# Altium schematic sheet CC2650RC_4MOD1106_RF_part_rev1_2.SchDoc(CC2650RC_4MOD1106_RF_part_rev1_2)
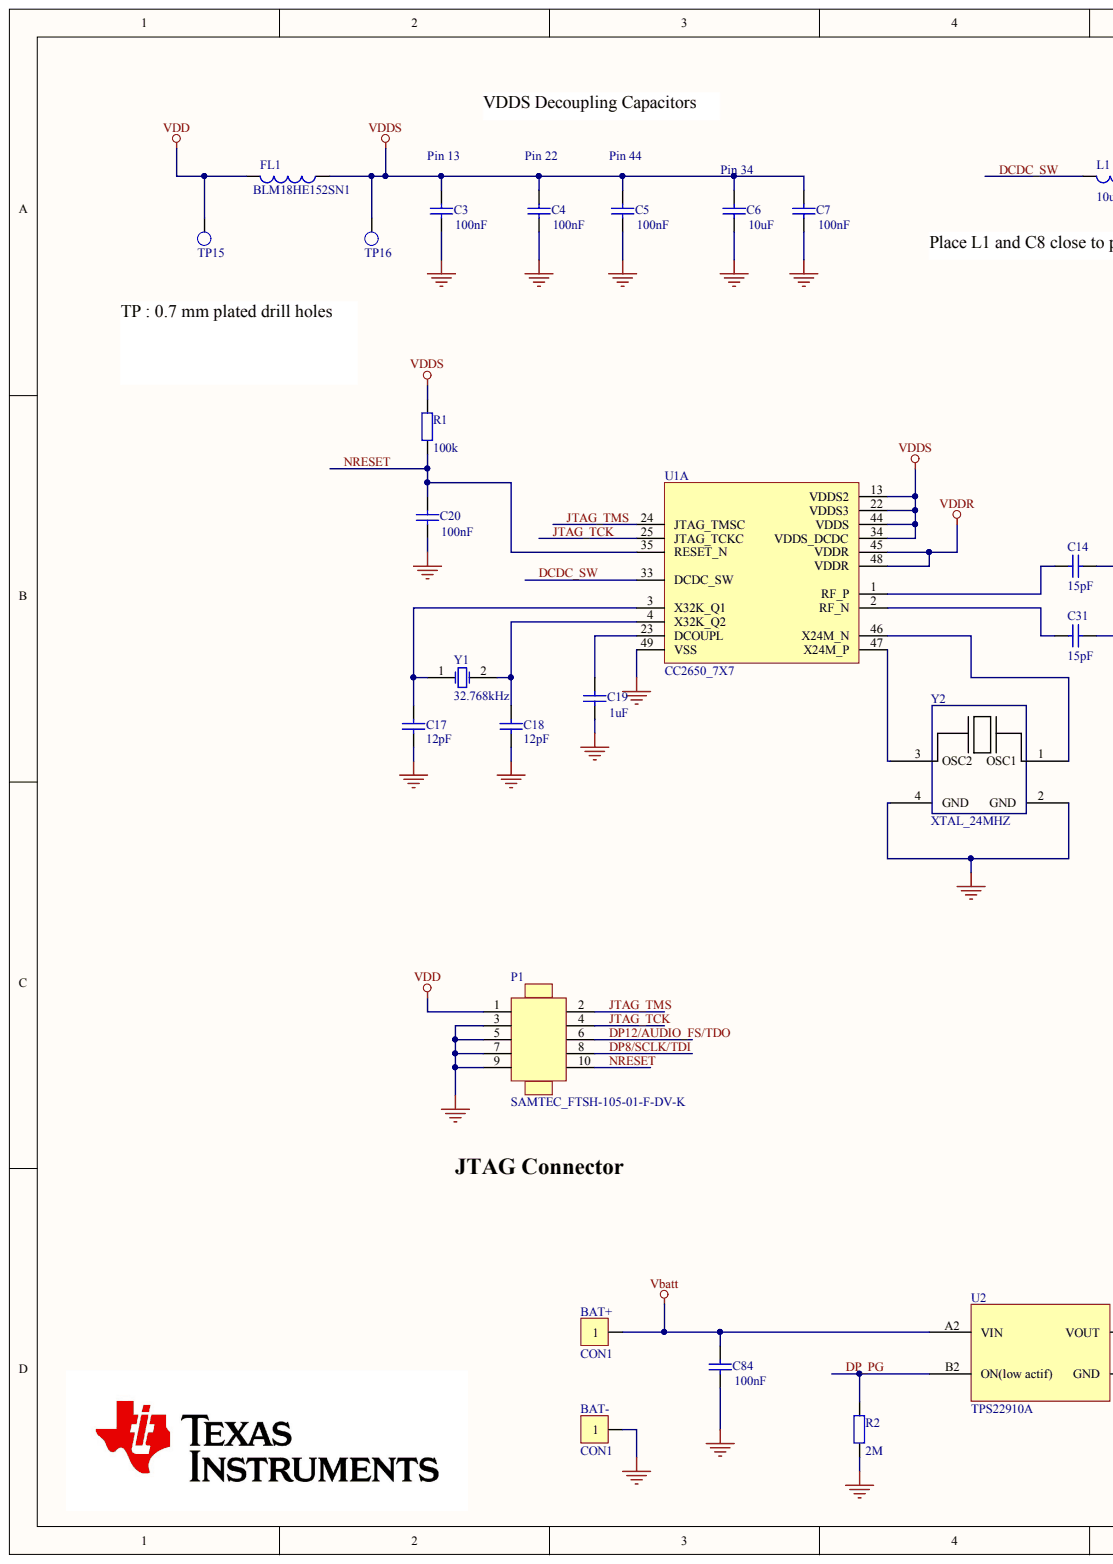
[diagram p0: CC2650RC_4MOD1106_RF_part_rev1_2.SchDoc, left]
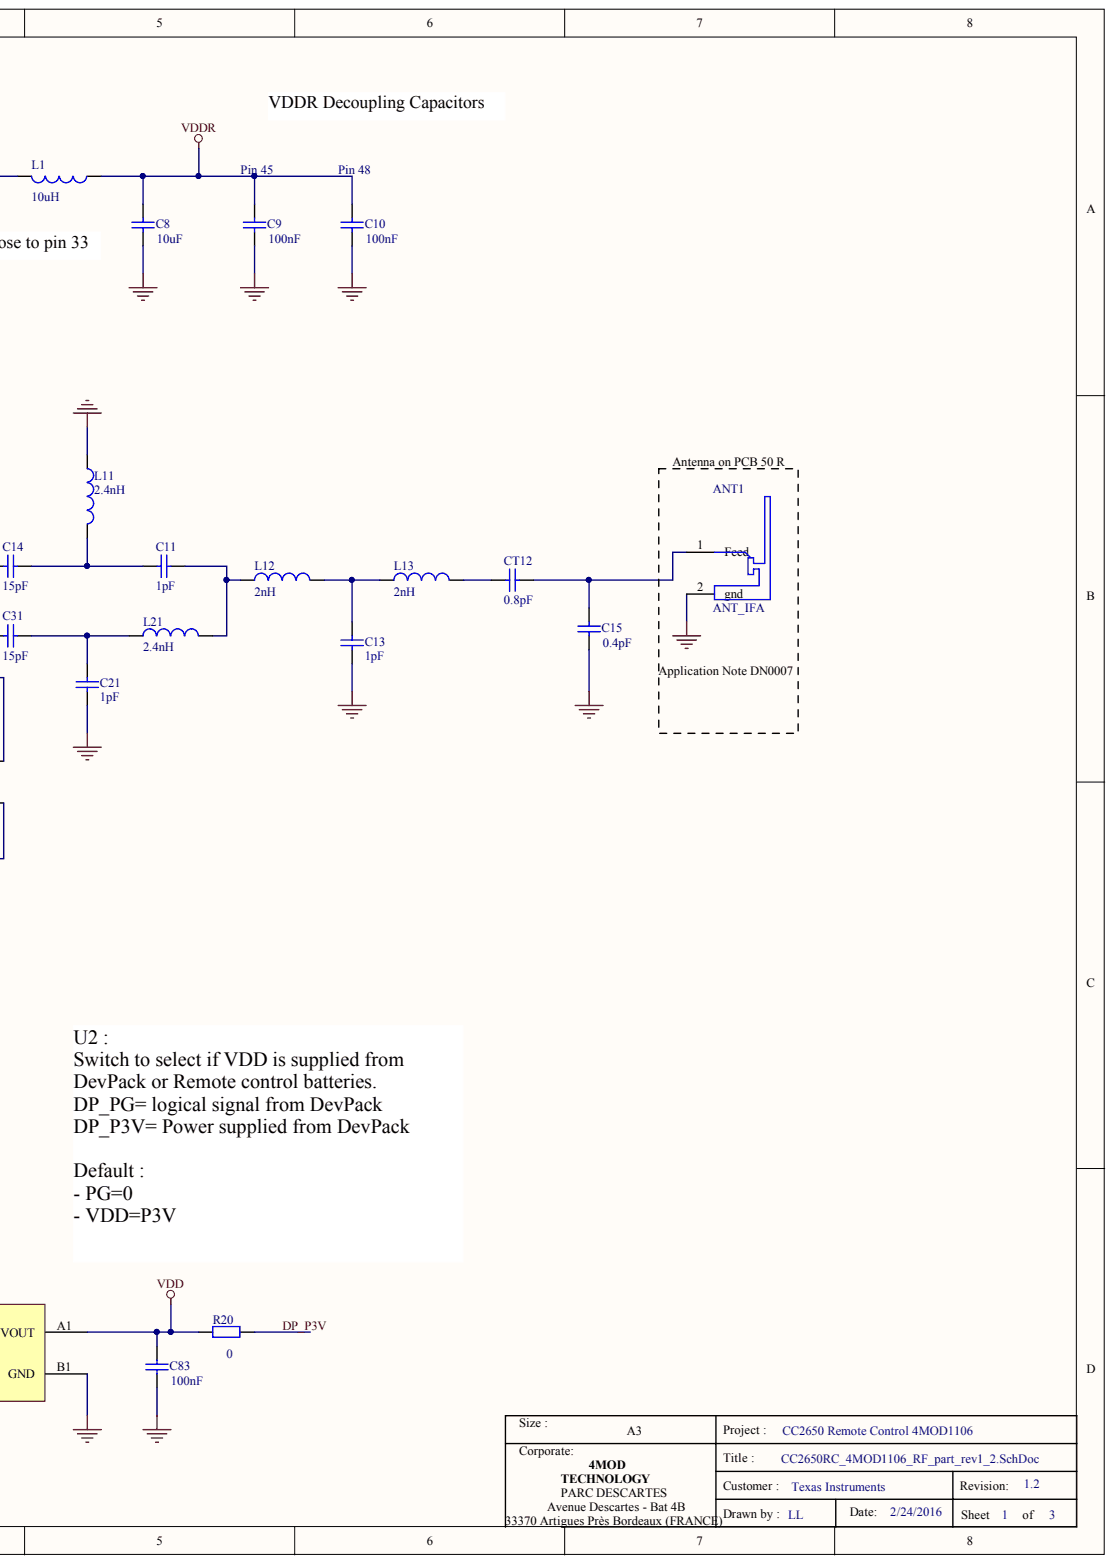
[diagram p1: CC2650RC_4MOD1106_RF_part_rev1_2.SchDoc, right]

## Parts
ANT1  ANT_IFA  [ANT_IFA_TI_DN0007]
BAT+  CON1  [SPRING_POSITIVE_4MOD1106]
BAT-  CON1  [SPRING_NEGATIVE_4MOD1106]
C3  Pin 13  value 100nF  [C402_4MOD]
C4  Pin 22  value 100nF  [C402_4MOD]
C5  Pin 44  value 100nF  [C402_4MOD]
C6  Pin 34  value 10uF  [C603_4MOD]
C7  value 100nF  [C402_4MOD]
C8  value 10uF  [C603_4MOD]
C9  Pin 45  value 100nF  [C402_4MOD]
C10  Pin 48  value 100nF  [C402_4MOD]
C11  value 1pF  [C402_4MOD]
C13  value 1pF  [C402_4MOD]
C14  value 15pF  [C402_4MOD]
C15  0.4pF  [C402_4MOD]
C17  value 12pF  [C402_4MOD]
C18  value 12pF  [C402_4MOD]
C19  value 1uF  [C402_4MOD]
C20  value 100nF  [C402_4MOD]
C21  value 1pF  [C402_4MOD]
C31  value 15pF  [C402_4MOD]
C83  value 100nF  [C402_4MOD]
C84  value 100nF  [C402_4MOD]
CT12  0.8pF  [C402_4MOD]
FL1  BLM18HE152SN1  [L0603_4MOD]
L1  10uH  [L0805_POWER_4MOD]
L11  value 2.4nH  [L0402_4MOD]
L12  value 2nH  [L0402_4MOD]
L13  value 2nH  [L0402_4MOD]
L21  value 2.4nH  [L0402_4MOD]
P1  SAMTEC_FTSH-105-01-F-DV-K  [HD2X5P127MM_POST]
R1  100k  [R402-4MOD]
R2  2M  [R402-4MOD]
R20  value 0  [R402-4MOD]
TP15  TEST POINT  [TP_CC2650RC]  (value not drawn)
TP16  TEST POINT  [TP_CC2650RC]  (value not drawn)
U1  CC2650_7X7  [CC26XX_QFN48(7x7)_0P5]  (part A)
U2  TPS22910A  [TPS22910A]
Y1  32.768kHz  [XTAL_SMD_3215(3.2X1.5)]
Y2  XTAL_24MHZ  [XTAL_SMD_3225(3.2X2.5)]

## Nets
GND: ANT1.2 BAT-.1 C3.1 C4.1 C5.1 C6.1 C7.1 C8.1 C9.1 C10.1 C13.2 C15.2 C17.1 C18.1 C19.1 C20.1 C21.2 C83.1 C84.1 L11.1 P1.3 P1.5 P1.7 P1.9 R2.2 U1.49(VSS) U2.B1(GND) Y2.2(GND) Y2.4(GND)
VBATT: BAT+.1 C84.2 U2.A2(VIN)
VDD: C83.2 FL1.2 P1.1 R20.1 TP15.1 U2.A1(VOUT)
VDDR: C8.2 C9.2 C10.2 L1.1 U1.45(VDDR) U1.48(VDDR)
VDDS: C3.2 C4.2 C5.2 C6.2 C7.2 FL1.1 R1.1 TP16.1 U1.13(VDDS2) U1.22(VDDS3) U1.34(VDDS_DCDC) U1.44(VDDS)
DCDC_SW: L1.2 U1.33(DCDC_SW)
DP8/SCLK/TDI: P1.8
DP12/AUDIO_FS/TDO: P1.6
DP_P3V: R20.2
DP_PG: R2.1 U2.B2(ON(low_actif))
JTAG_TCK: P1.4 U1.25(JTAG_TCKC)
JTAG_TMS: P1.2 U1.24(JTAG_TMSC)
NRESET: C20.2 P1.10 R1.2 U1.35(RESET_N)
NetANT1_1: ANT1.1 C15.1 CT12.2
NetC11_1: C11.1 L12.1 L21.2
NetC11_2: C11.2 C14.1 L11.2
NetC13_1: C13.1 L12.2 L13.1
NetC14_2: C14.2 U1.1(RF_P)
NetC17_2: C17.2 U1.3(X32K_Q1) Y1.1
NetC18_2: C18.2 U1.4(X32K_Q2) Y1.2
NetC19_2: C19.2 U1.23(DCOUPL)
NetC21_1: C21.1 C31.1 L21.1
NetC31_2: C31.2 U1.2(RF_N)
NetCT12_1: CT12.1 L13.2
NetU1_46: U1.46(X24M_N) Y2.1(OSC1)
NetU1_47: U1.47(X24M_P) Y2.3(OSC2)

## Library data of the parts
ANT1 = INVERTED_F_ANTENNA_IFA_v2: Antenna on PCB , IFA type, version 2; Component Kind: Standard; Library Name: 4MOD_PASSIVE.SCHLIB
BAT+ BAT- = CON1: Point test; Component Kind: Standard; PCB3D: HDR1x1; Library Name: PASSIF.SCHLIB
C3 = Cap: Capacitor, Ceramic X7R, 100nF, 6.3V, +/- 10%, 0402; Component Kind: Standard; LatestRevisionDate: 17-Jul-2002; LatestRevisionNote: Re-released for DXP Platform.; Library Name: Miscellaneous Devices.IntLib; PackageReference: RAD-0.3; Published: 8-Jun-2000; Signal Integrity: Cap; Simulation: CAP; Publisher: Altium Limited
C4 C5 C7 C9 C10 C20 C83 C84 = Cap: Capacitor, Ceramic X7R, 100nF, 6.3V, +/- 10%, SMD  case 0402; Component Kind: Standard; LatestRevisionDate: 17-Jul-2002; LatestRevisionNote: Re-released for DXP Platform.; Library Name: Miscellaneous Devices.IntLib; PackageReference: RAD-0.3; Published: 8-Jun-2000; Signal Integrity: Cap; Simulation: CAP; Publisher: Altium Limited
C6 C8 = Cap: Capacitor, Ceramic X5R, 10uF, 6.3V, +/- 10%, SMD case 0603; Component Kind: Standard; LatestRevisionDate: 17-Jul-2002; LatestRevisionNote: Re-released for DXP Platform.; Library Name: Miscellaneous Devices.IntLib; PackageReference: RAD-0.3; Published: 8-Jun-2000; Signal Integrity: Cap; Simulation: CAP; Publisher: Altium Limited
C11 C13 C21 = Cap: Ceramic Capacitor , NPO/COG, 1pF , 50V, 0.25pF, -55/+125 °C, smd case 0402; Component Kind: Standard; LatestRevisionDate: 17-Jul-2002; LatestRevisionNote: Re-released for DXP Platform.; Library Name: Miscellaneous Devices.IntLib; PackageReference: RAD-0.3; Published: 8-Jun-2000; Signal Integrity: Cap; Simulation: CAP; Publisher: Altium Limited
C14 = Cap: Ceramic Capacitor , NPO/COG, 15pF ,50V,  5 %, -55/+125 °C, smd case 0402; Component Kind: Standard; LatestRevisionDate: 17-Jul-2002; LatestRevisionNote: Re-released for DXP Platform.; Library Name: Miscellaneous Devices.IntLib; PackageReference: RAD-0.3; Published: 8-Jun-2000; Signal Integrity: Cap; Simulation: CAP; Publisher: Altium Limited
C15 = Cap: Ceramic Capacitor , NPO/COG, 0.4pF , 50V, 0.05pF, -55/+125 °C, smd case 0402; Component Kind: Standard; LatestRevisionDate: 17-Jul-2002; LatestRevisionNote: Re-released for DXP Platform.; Library Name: Miscellaneous Devices.IntLib; PackageReference: RAD-0.3; Published: 8-Jun-2000; Signal Integrity: Cap; Simulation: CAP; Value: 0.4pF; Publisher: Altium Limited
C17 C18 = Cap: Ceramic Capacitor, NPO/COG,12pF, 50V,+/-5%,-55/125°C, SMD case 0402; Component Kind: Standard; LatestRevisionDate: 17-Jul-2002; LatestRevisionNote: Re-released for DXP Platform.; Library Name: Miscellaneous Devices.IntLib; PackageReference: RAD-0.3; Published: 8-Jun-2000; Signal Integrity: Cap; Simulation: CAP; Publisher: Altium Limited
C19 = Cap: Ceramic Capacitor , X5V, 1uF, 6.3V, +/- 20%, SMD case 0402; Component Kind: Standard; LatestRevisionDate: 17-Jul-2002; LatestRevisionNote: Re-released for DXP Platform.; Library Name: Miscellaneous Devices.IntLib; PackageReference: RAD-0.3; Published: 8-Jun-2000; Signal Integrity: Cap; Simulation: CAP; Publisher: Altium Limited
C31 = Cap: Ceramic Capacitor , NPO/COG, 15pF ,50V,  5 %, -55/+125 °C, smd case; Component Kind: Standard; LatestRevisionDate: 17-Jul-2002; LatestRevisionNote: Re-released for DXP Platform.; Library Name: Miscellaneous Devices.IntLib; PackageReference: RAD-0.3; Published: 8-Jun-2000; Signal Integrity: Cap; Simulation: CAP; Publisher: Altium Limited
CT12 = Cap: Ceramic Capacitor , NPO/COG, 0.8pF , 50V, +/-0.05pF, -55/+125 °C, smd case 0402; Component Kind: Standard; LatestRevisionDate: 17-Jul-2002; LatestRevisionNote: Re-released for DXP Platform.; Library Name: Miscellaneous Devices.IntLib; PackageReference: RAD-0.3; Published: 8-Jun-2000; Signal Integrity: Cap; Simulation: CAP; Value: 0.8pF; Publisher: Altium Limited
FL1 = Inductor: FILTER, EMI, 1500@100MHz, -55DEGC/+125DEGC,BLM18HE152SN1 ,  SMD case 0603; Manufacturer: Generic Components; Class: Passive; Value: 1k5; Component Kind: Standard; Library Name: Miscellaneous Devices.IntLib; Published: 08/06/2000; Signal Integrity: Inductor; Simulation: INDUCTOR; SubClass: Inductor; Publisher: Altium Hobart Technology Centre
L1 = Inductor: Inductor, 2u2H, 0805, ±30%; Manufacturer: Generic Components; Class: Passive; Component Kind: Standard; Library Name: Miscellaneous Devices.IntLib; Published: 08/06/2000; Signal Integrity: Inductor; Simulation: INDUCTOR; SubClass: Inductor; Value: 10uH; Publisher: Altium Hobart Technology Centre
L11 L21 = Inductor: Inductor, 2.4nH , +/- 0.3nH , 300mA ,-55/+125 °C, smd case 0402; Manufacturer: Generic Components; Class: Passive; Component Kind: Standard; Library Name: Miscellaneous Devices.IntLib; Published: 08/06/2000; Signal Integrity: Inductor; Simulation: INDUCTOR; SubClass: Inductor; Publisher: Altium Hobart Technology Centre
L12 L13 = Inductor: Inductor, 2nH , +/- 0.3nH , 300mA ,-55/+125 °C, smd case 0402; Manufacturer: Generic Components; Class: Passive; Component Kind: Standard; Library Name: Miscellaneous Devices.IntLib; Published: 08/06/2000; Signal Integrity: Inductor; Simulation: INDUCTOR; SubClass: Inductor; Publisher: Altium Hobart Technology Centre
P1 = SAMTEC_FTSH-105-01-F-DV-K: CON HEADER 10POS DUAL .05" SMD, Rectangular Connector; Component Kind: Standard; Library Name: 4MOD_PASSIVE.SCHLIB
R1 = Res2: Resistor, Thick Film, 100k, +/- 1% 50V 0.063W, SMD case 0402; Component Kind: Standard; LatestRevisionDate: 17-Jul-2002; LatestRevisionNote: Re-released for DXP Platform.; Library Name: Miscellaneous Devices.IntLib; PackageReference: AXIAL-0.4; Published: 8-Jun-2000; Signal Integrity: Res; Simulation: RESISTOR; Value: 100k; Publisher: Altium Limited
R2 = Res2: Resistor, Thick Film ,2M, +/- 1% 50V 0.063W, SMD case 0402; Component Kind: Standard; LatestRevisionDate: 17-Jul-2002; LatestRevisionNote: Re-released for DXP Platform.; Library Name: Miscellaneous Devices.IntLib; PackageReference: AXIAL-0.4; Published: 8-Jun-2000; Signal Integrity: Res; Simulation: RESISTOR; Value: 2M; Publisher: Altium Limited
R20 = Res2: Resistor , 0R, Jumper , SMD case 0402; Manufacturer: Generic Components; Class: Passive; setting: DNM; Component Kind: Standard; Library Name: Miscellaneous Devices.IntLib; Published: 08/06/2000; Signal Integrity: Res2; Simulation: RESISTOR; SubClass: Resistor; Publisher: Altium Hobart Technology Centre
TP15 TP16 = POINT TEST: Component Kind: Standard; Description: Point test; PCB3D: HDR1x1; Library Name: PASSIF.SCHLIB
U1 = CC2650_7X7: CC2650, SimpleLink multi-standard 2.4 GHz ultra-low power wireless MCU, Texas Instruments , smd case VQFN48 ( 7mmx7mm); Component Kind: Standard; Library Name: 4MOD_Devices.SCHLIB
U2 = TPS22910A: TPS22910A, Ultra-small, Low On Resistance Load Switch With Controlled Turn-on, Texas Instruments, smd case DSBGA-4; Component Kind: Standard; Library Name: 4MOD_Devices.SCHLIB
Y1 = XTAL: Crystal Oscillator - FC-135 32.7680KA-AC - EPSON ELECTRONICS AMERICA; Component Kind: Standard; LatestRevisionDate: 17-Jul-2002; LatestRevisionNote: Re-released for DXP Platform.; Library Name: Miscellaneous Devices.IntLib; PackageReference: R38; Published: 8-Jun-2000; Simulation: XTAL; Publisher: Altium Limited
Y2 = XTAL_FA-128: XTAL, 24MHz 10pF 10PPM/10PPM; ESR=60R max, -40 to 85° C , SMD case 3225(3.2mmX2.5mm); Component Kind: Standard; Library Name: 4MOD.SchLib
